# Altium schematic sheet Servo.SchDoc(Servo)
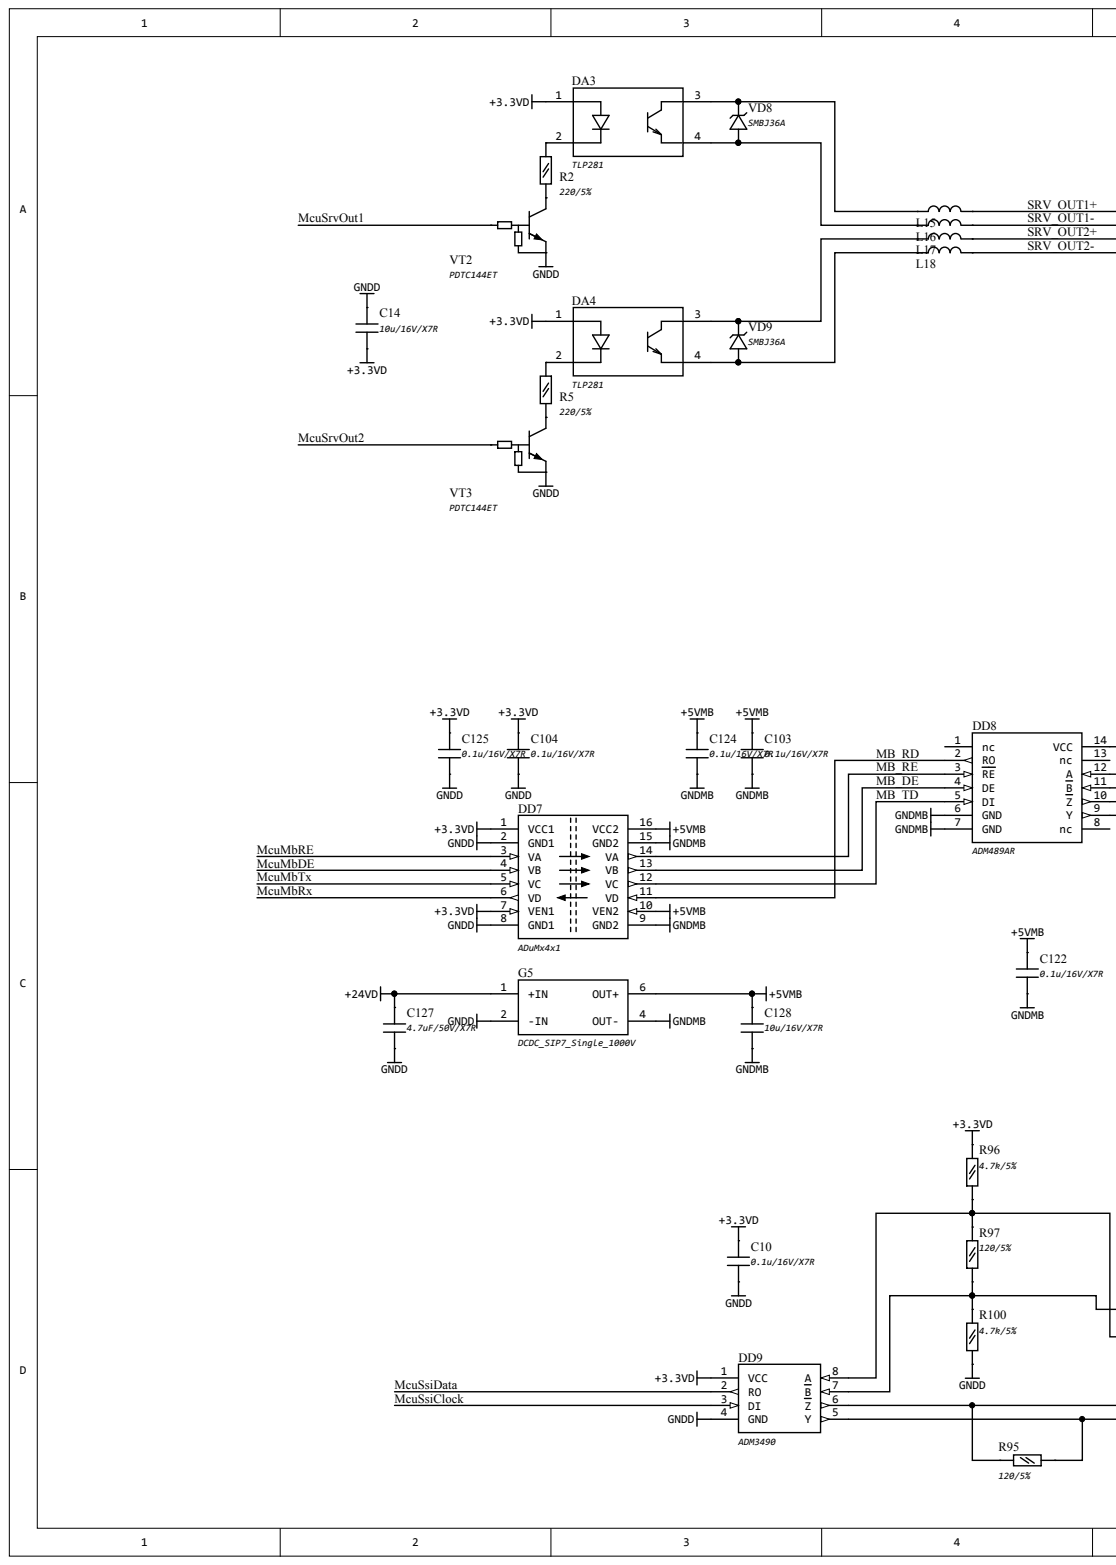
[diagram p0: Servo.SchDoc, left]
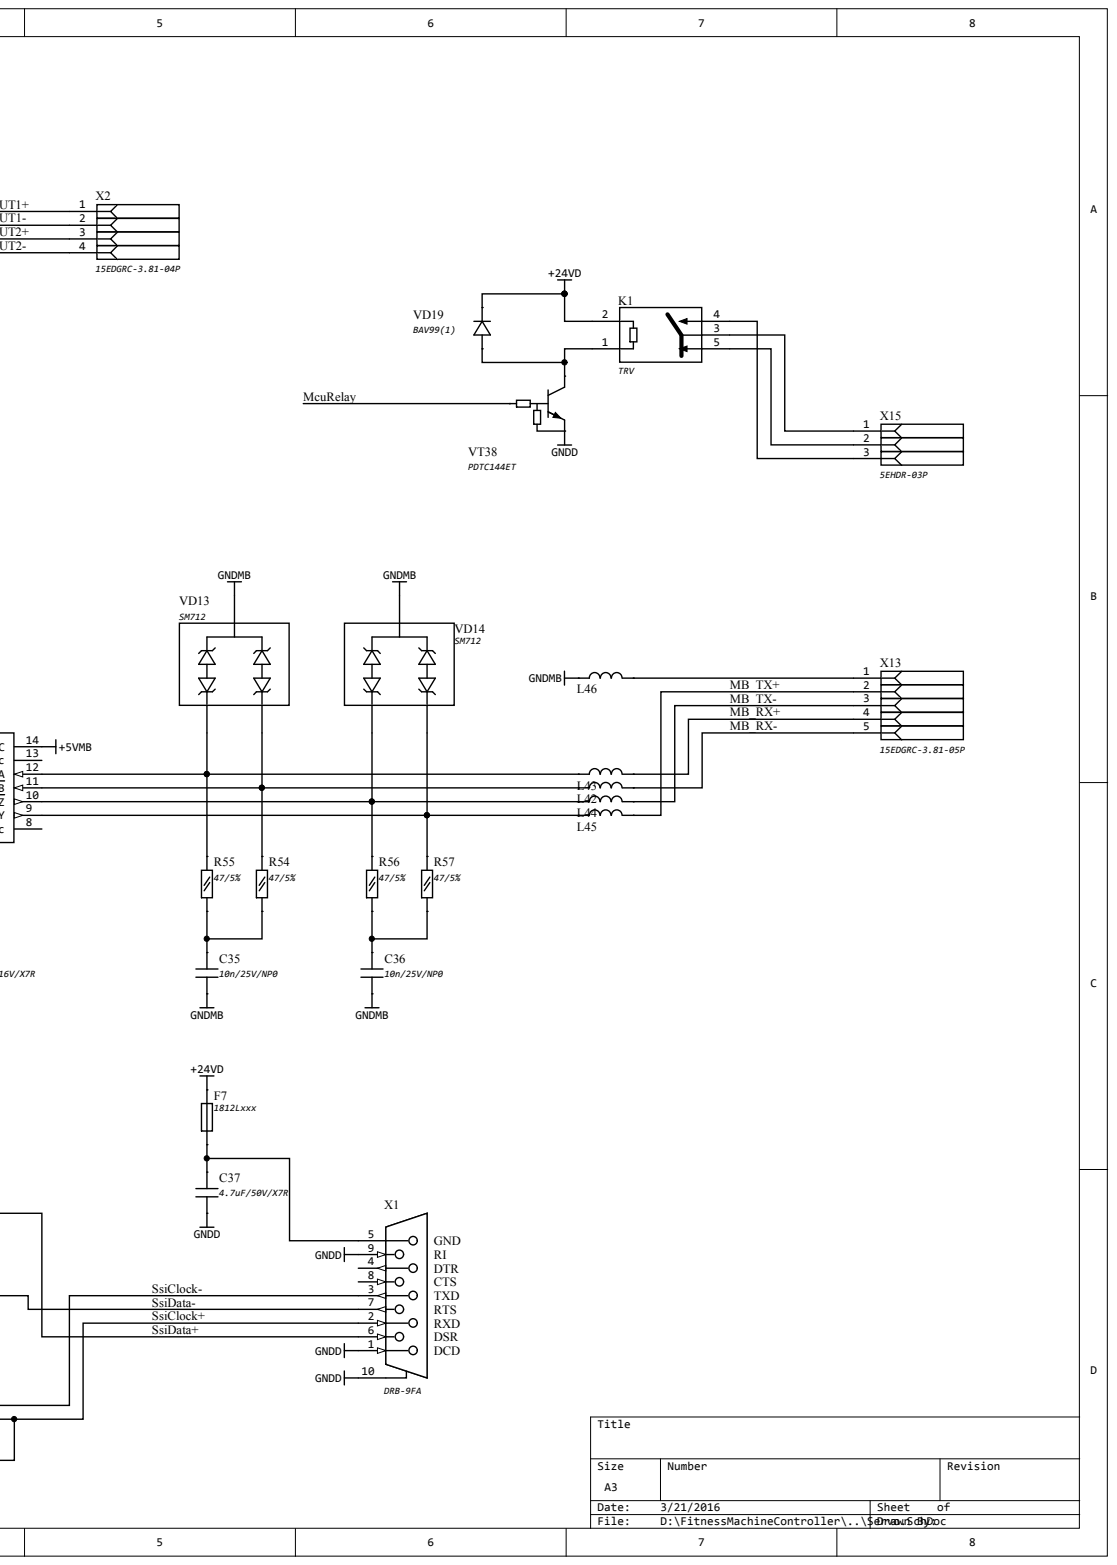
[diagram p1: Servo.SchDoc, right]

## Parts
C10  0.1u/16V/X7R
C14  10u/16V/X7R
C35  10n/25V/NP0
C36  10n/25V/NP0
C37  4.7uF/50V/X7R
C103  0.1u/16V/X7R
C104  0.1u/16V/X7R
C122  0.1u/16V/X7R
C124  0.1u/16V/X7R
C125  0.1u/16V/X7R
C127  4.7uF/50V/X7R
C128  10u/16V/X7R
DA3  TLP281
DA4  TLP281
DD7  ADuMx4x1
DD8  ADM489AR
DD9  ADM3490
F7  1812Lxxx
G5  DCDC_SIP7_Single_1000V
K1  TRV
L15  FerriteFilterBLM18  (value not drawn)
L16  FerriteFilterBLM18  (value not drawn)
L17  FerriteFilterBLM18  (value not drawn)
L18  FerriteFilterBLM18  (value not drawn)
L42  FerriteFilterBLM18  (value not drawn)
L43  FerriteFilterBLM18  (value not drawn)
L44  FerriteFilterBLM18  (value not drawn)
L45  FerriteFilterBLM18  (value not drawn)
L46  FerriteFilterBLM18  (value not drawn)
R2  220/5%
R5  220/5%
R54  47/5%
R55  47/5%
R56  47/5%
R57  47/5%
R95  120/5%
R96  4.7k/5%
R97  120/5%
R100  4.7k/5%
VD8  SMBJ36A
VD9  SMBJ36A
VD13  SM712
VD14  SM712
VD19  BAV99(1)
VT2  PDTC144ET
VT3  PDTC144ET
VT38  PDTC144ET
X1  DRB-9FA
X2  15EDGRC-3.81-04P
X13  15EDGRC-3.81-05P
X15  5EHDR-03P

## Nets
+3.3VD: C10.2 C14.2 C104.2 C125.2 DA3.1 DA4.1 DD7.1(VCC1) DD7.7(VEN1) DD9.1(VCC) R96.1
+5VMB: C103.2 C122.2 C124.2 C128.2 DD7.10(VEN2) DD7.16(VCC2) DD8.14(VCC) G5.6(OUT+)
+24VD: C127.2 F7.1 G5.1(+IN) K1.2 VD19.3
GNDD: C10.1 C14.1 C37.1 C104.1 C125.1 C127.1 DD7.2(GND1) DD7.8(GND1) DD9.4(GND) G5.2(-IN) R100.2 VT2.2 VT3.2 VT38.2 X1.1 X1.9 X1.10
GNDMB: C35.1 C36.1 C103.1 C122.1 C124.1 C128.1 DD7.9(GND2) DD7.15(GND2) DD8.6(GND) DD8.7(GND) G5.4(OUT-) L46.1 VD13.3 VD14.3
MB_DE: DD7.13(VB) DD8.4(DE)
MB_RD: DD7.11(VD) DD8.2(RO)
MB_RE: DD7.14(VA) DD8.3(RE)
MB_RX+: L43.2 X13.4
MB_RX-: L42.2 X13.5
MB_TD: DD7.12(VC) DD8.5(DI)
MB_TX+: L45.2 X13.2
MB_TX-: L44.2 X13.3
MCUMBDE: DD7.4(VB)
MCUMBRE: DD7.3(VA)
MCUMBRX: DD7.6(VD)
MCUMBTX: DD7.5(VC)
MCURELAY: VT38.1
MCUSRVOUT1: VT2.1
MCUSRVOUT2: VT3.1
MCUSSICLOCK: DD9.3(DI)
MCUSSIDATA: DD9.2(RO)
SRV_OUT1+: L15.2 X2.1
SRV_OUT1-: L16.2 X2.2
SRV_OUT2+: L17.2 X2.3
SRV_OUT2-: L18.2 X2.4
SSICLOCK+: DD9.5(Y) R95.2 X1.2
SSICLOCK-: DD9.6(Z) R95.1 X1.3
SSIDATA+: DD9.8(A) R96.2 R97.1 X1.6
SSIDATA-: DD9.7(B) R97.2 R100.1 X1.7
NetC35_2: C35.2 R54.2 R55.2
NetC36_2: C36.2 R56.2 R57.2
NetC37_2: C37.2 F7.2 X1.5
NetDA3_2: DA3.2 R2.2
NetDA3_3: DA3.3 L15.1 VD8.2
NetDA3_4: DA3.4 L16.1 VD8.1
NetDA4_2: DA4.2 R5.2
NetDA4_3: DA4.3 L17.1 VD9.2
NetDA4_4: DA4.4 L18.1 VD9.1
NetDD8_9: DD8.9(Y) L45.1 R57.1 VD14.2
NetDD8_10: DD8.10(Z) L44.1 R56.1 VD14.1
NetDD8_11: DD8.11(B) L42.1 R54.1 VD13.1
NetDD8_12: DD8.12(A) L43.1 R55.1 VD13.2
NetK1_1: K1.1 VD19.1 VT38.3
NetK1_3: K1.3 X15.1
NetK1_4: K1.4 X15.3
NetK1_5: K1.5 X15.2
NetL46_2: L46.2 X13.1
NetR2_1: R2.1 VT2.3
NetR5_1: R5.1 VT3.3

Not connected: DD8 1(nc) 8(nc) 13(nc); X1 4 8

## Library data of the parts
C10 C35 C36 C103 C104 C122 C124 C125 = (no symbol name): ComponentDescriptionRUS: Конденсатор SMD 0603; Analog: нет; NumberSolderPointsSMD: 0; NumberSolderPointsTHT: 0
C14 C128 = (no symbol name): ComponentDescriptionRUS: Конденсатор SMD 1206; Analog: нет; NumberSolderPointsSMD: 0; NumberSolderPointsTHT: 0
C37 C127 = (no symbol name): ComponentDescriptionRUS: Конденсатор SMD 1210; Analog: нет; NumberSolderPointsSMD: 0; NumberSolderPointsTHT: 0
DA3 DA4 = (no symbol name): ComponentDescriptionRUS: Оптрон; Аналог: TLP291
DD7 X1 = (no symbol name): Analog: нет; NumberSolderPointsSMD: 0; NumberSolderPointsTHT: 0
DD8 = (no symbol name): Аналог: MAX489ESD; NumberSolderPointsSMD: Микросхема SO14; NumberSolderPointsTHT: 0
DD9 = (no symbol name): ComponentDescriptionRUS: Микросхема SO8; Аналог: нет; NumberSolderPointsSMD: 0; NumberSolderPointsTHT: 0
F7 = (no symbol name): ComponentDescriptionRUS: Предохранитель; Analog: нет; NumberSolderPointsSMD: 0; NumberSolderPointsTHT: 0
G5 = (no symbol name): ComponentDescriptionRUS: Модуль питания; Analog: нет; NumberSolderPointsSMD: 0; NumberSolderPointsTHT: 0
K1 VD19 = (no symbol name): NumberSolderPointsSMD: 0; Аналог: нет; NumberSolderPointsTHT: 0
L15-L18 L42-L46 = (no symbol name): ComponentDescriptionRUS: Фильтр SMD 0603; Analog: нет; NumberSolderPointsSMD: 0; NumberSolderPointsTHT: 0
R2 R5 R54-R57 R95-R97 R100 = (no symbol name): ComponentDescriptionRUS: Резистор SMD 0603; Analog: нет; NumberSolderPointsSMD: 0; NumberSolderPointsTHT: 0
VD8 VD9 VD13 VD14 = (no symbol name): Описание: Диод SMB; NumberSolderPointsSMD: 0; Аналог: нет; NumberSolderPointsTHT: 0
VT2 VT3 VT38 = (no symbol name): ComponentDescriptionRUS: Транзистор SOT23; Analog: нет; NumberSolderPointsSMD: 0; NumberSolderPointsTHT: 0
X2 = (no symbol name): Аналог: ECH381R-04P; NumberSolderPointsSMD: 0; Комплект: EC381V-04P, 15EDGK-3.81-04; NumberSolderPointsTHT: 0
X13 = (no symbol name): Аналог: ECH381R-05P; NumberSolderPointsSMD: 0; Комплект: EC381V-05P, 15EDGK-3.81-05; NumberSolderPointsTHT: 0
X15 = (no symbol name): Аналог: ECH381R-03P; NumberSolderPointsSMD: 0; Комплект: 5ESDV-03P; NumberSolderPointsTHT: 0
